# Altium schematic sheet Connectors.SchDoc(Connectors)
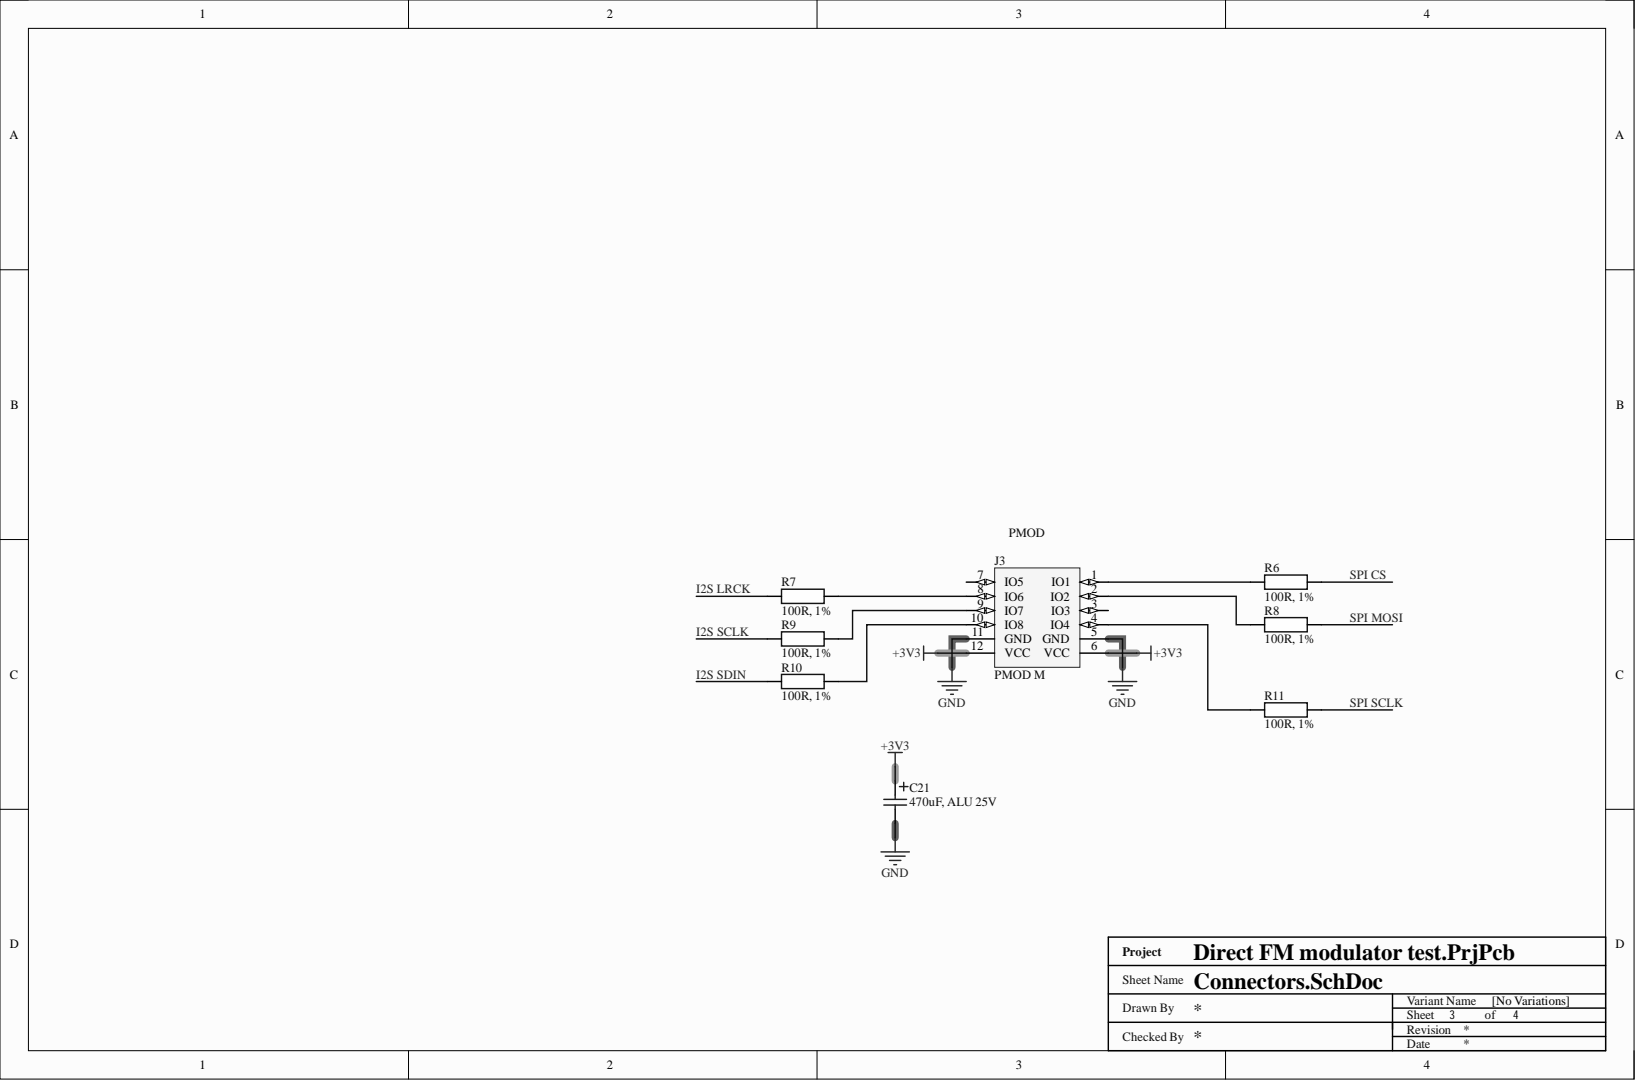
[diagram p0: Connectors.SchDoc]

## Parts
C21  470uF, ALU 25V  [WCAP-ATG8_8x11.5x3.5]
J3  PMOD M  [PMOD M]
R6  100R, 1%  [SMD0402 - R]
R7  100R, 1%  [SMD0402 - R]
R8  100R, 1%  [SMD0402 - R]
R9  100R, 1%  [SMD0402 - R]
R10  100R, 1%  [SMD0402 - R]
R11  100R, 1%  [SMD0402 - R]

## Nets
+3V3: C21.1 J3.6(VCC) J3.12(VCC)
GND: C21.2 J3.5(GND) J3.11(GND)
I2S LRCK: R7.1
I2S SCLK: R9.1
I2S SDIN: R10.1
SPI CS: R6.2
SPI MOSI: R8.2
SPI SCLK: R11.2
NetJ3_1: J3.1(IO1) R6.1
NetJ3_2: J3.2(IO2) R8.1
NetJ3_4: J3.4(IO4) R11.1
NetJ3_8: J3.8(IO6) R7.2
NetJ3_9: J3.9(IO7) R9.2
NetJ3_10: J3.10(IO8) R10.2

Not connected: J3 3(IO3) 7(IO5)

## Library data of the parts
C21 = 860010474013: WURTH ELEKTRONIK - 860010474013 - CAP, ALU ELEC, 470UF, 25V, RADIAL; Capacitance: 470u; Capacitance Tolerance: ± 20%; Capacitor Terminals: Radial Leaded; Lifetime @ Temperature: 2000 hours @ 85; Product Range: WCAP-ATG8 Series; SVHC: No SVHC (07-Jul-2017); Automotive Qualification Standard: -; Component Kind: Standard; ComponentLink1Description: http://www.farnell.com/datasheets/1879524.pdf; ComponentLink1URL: http://www.farnell.com/datasheets/1879524.pdf; Diameter: 8; ESR: -; Height: 11.5; Lead Spacing: 3.5; Library Name: Capacitors.IntLib; Manufacturer: WURTH ELEKTRONIK; Manufacturer Part Number: 860010474013; MSL: MSL 1 - Unlimited; Operating Temperature Max: 85; Operating Temperature Min: -40; Packaging: Each<!--FANDB--><div class="features-and-benefits-container"><div class="features-and-benefits-desc-container"><span class="features-and-benefits-container-desc">Electrolytic Capacitor, 470 µF, 25 V, WCAP-ATG8 Series, ± 20%, Radial Leaded, 8 mm</span></div></div>; Pricing 1: 1=0.313, 3=0.282, 5=0.257, 10=0.232, 20=0.23, 50=0.189, 250=0.18 (GBP); RoHS: YES; RoHS Phthalates Compliant: Yes; Stock 1: 134; Supplier 1: Farnell; Supplier 2: Digi-Key; Supplier 3: Mouser; Supplier Part Number 1: 2465681; Supplier Part Number 2: 732-8636-1-ND; Supplier Part Number 3: 710-860010474013; Voltage Rating: 25V
J3 = PMOD M: WR-PHD 2.54 mm THT Angled Dual Pin Header, 12 pins; Manufacturer: Wurth Electronics; Manufacturer Part Number: 61301221021; Connector Type: Male Pin; Gender: Male; Number of Contacts: 12; Pitch: 0.1inch; Category: Connectors; Color: Black; Component Kind: Standard; ComponentLink1Description: https://katalog.we-online.de/em/datasheet/6130xx21021.pdf?src-supplier=Digi-Key; ComponentLink1URL: https://katalog.we-online.de/em/datasheet/6130xx21021.pdf?src-supplier=Digi-Key; ComponentLink2Description: http://www.farnell.com/cad/1737270.pdf?src-supplier=Element14; ComponentLink2URL: http://www.farnell.com/cad/1737270.pdf?src-supplier=Element14; Contact Material: Copper; Contact Mating Length: 0.236inch; Contact Plating: Gold; Library Name: Connectors.SchLib; Mount: Through Hole; Number of Positions: 12; Number of Rows: 2; Packaging: Bulk; Pricing 1: 1=0.94000, 10=0.79300, 50=0.55100, 100=0.50100, 1000=0.45500, 10000=0.45500 (USD); REACH SVHC: Unknown; RoHS: Yes; RoHS Compliant: Yes; Row Spacing: 0.1inch; Supplier 1: Mouser; Supplier 2: Farnell; Supplier 3: Digi-Key; Supplier 4: RSComponents; Supplier Part Number 1: 710-61301221021; Supplier Part Number 2: 2356197; Supplier Part Number 3: 732-5354-ND; Supplier Part Number 4: 8281711; Termination: Solder
R6-R11 = RC0402FR-07100RL: YAGEO - RC0402FR-07100RL - RES, 100R, 1%, 0.0625W, 0402, THICK FILM; Power Rating: 62.5; Product Range: RC Series; Reel Quantity: 10000<!--FANDB--><div class="features-and-benefits-container"><div class="features-and-benefits-desc-container"><span class="features-and-benefits-container-desc">SMD Chip Resistor, 0402 [1005 Metric], 100 ohm, RC Series, 50 V, Thick Film, 62.5 mW</span></div><div class="features-and-benefits-description"><span class="features-benefits-value">The Yageo RC_L series resistors are general purpose thick film chip resistors with lead free terminations made by thick film process. The resistance range includes E24 and E96 decade values from 1ohm to 100Mohm. These resistors are constructed on top of a high grade ceramic body.</span></div><div class="features-and-benefits-list"><ul class="features-and-benefits-list-list"><li class="features-and-benefits-list-item">EIA 0075, 0100, 0201, 0402, 0603, 0805, 1206, 1210, 1218, 2010, 2512 case sizes available</li><li class="features-and-benefits-list-item">Small chip sizes save PCB space</li><li class="features-and-benefits-list-item">Rated voltage range of 10V to 200V</li><li class="features-and-benefits-list-item">Maximum power rating of 2W</li><li class="features-and-benefits-list-item">Available in ±0.1%, ±0.5%, ±1% and ±5% tolerance</li><li class="features-and-benefits-list-item">High component and equipment reliability</li><li class="features-and-benefits-list-item">Forbidden materials are not used in products/production</li><li class="features-and-benefits-list-item">-55°C to 155°C temperature range for RC0402 to RC2512 and -55°C to 125°C for RC0075 to RC0201; Resistance: 100; Resistor Case Style: 0402 [1005 Metric]; SVHC: No SVHC (27-Jun-2018); Temperature Coefficient: ± 100; Voltage Rating: 50; Automotive Qualification Standard: -; Component Kind: Standard; ComponentLink1Description: http://www.farnell.com/datasheets/2167052.pdf; ComponentLink1URL: http://www.farnell.com/datasheets/2167052.pdf; Library Name: SMD0402Res.IntLib; Manufacturer: YAGEO; Manufacturer Part Number: RC0402FR-07100RL; MSL: MSL 1 - Unlimited; Operating Temperature Max: 155; Operating Temperature Min: -55; Pricing 1: 10=0.385, 100=0.38, 500=0.285, 2500=0.144, 5000=0.121 (EUR); Resistance Tolerance: ± 1%; Resistor Element Material: Thick Film; RoHS: YES; Stock 1: 260492; Supplier 1: Farnell; Supplier 2: Mouser; Supplier Part Number 1: 9239111; Supplier Part Number 2: 603-RC0402FR-07100RL
